# Altium schematic sheet MOSFET Driver.SchDoc("MOSFET Driver")
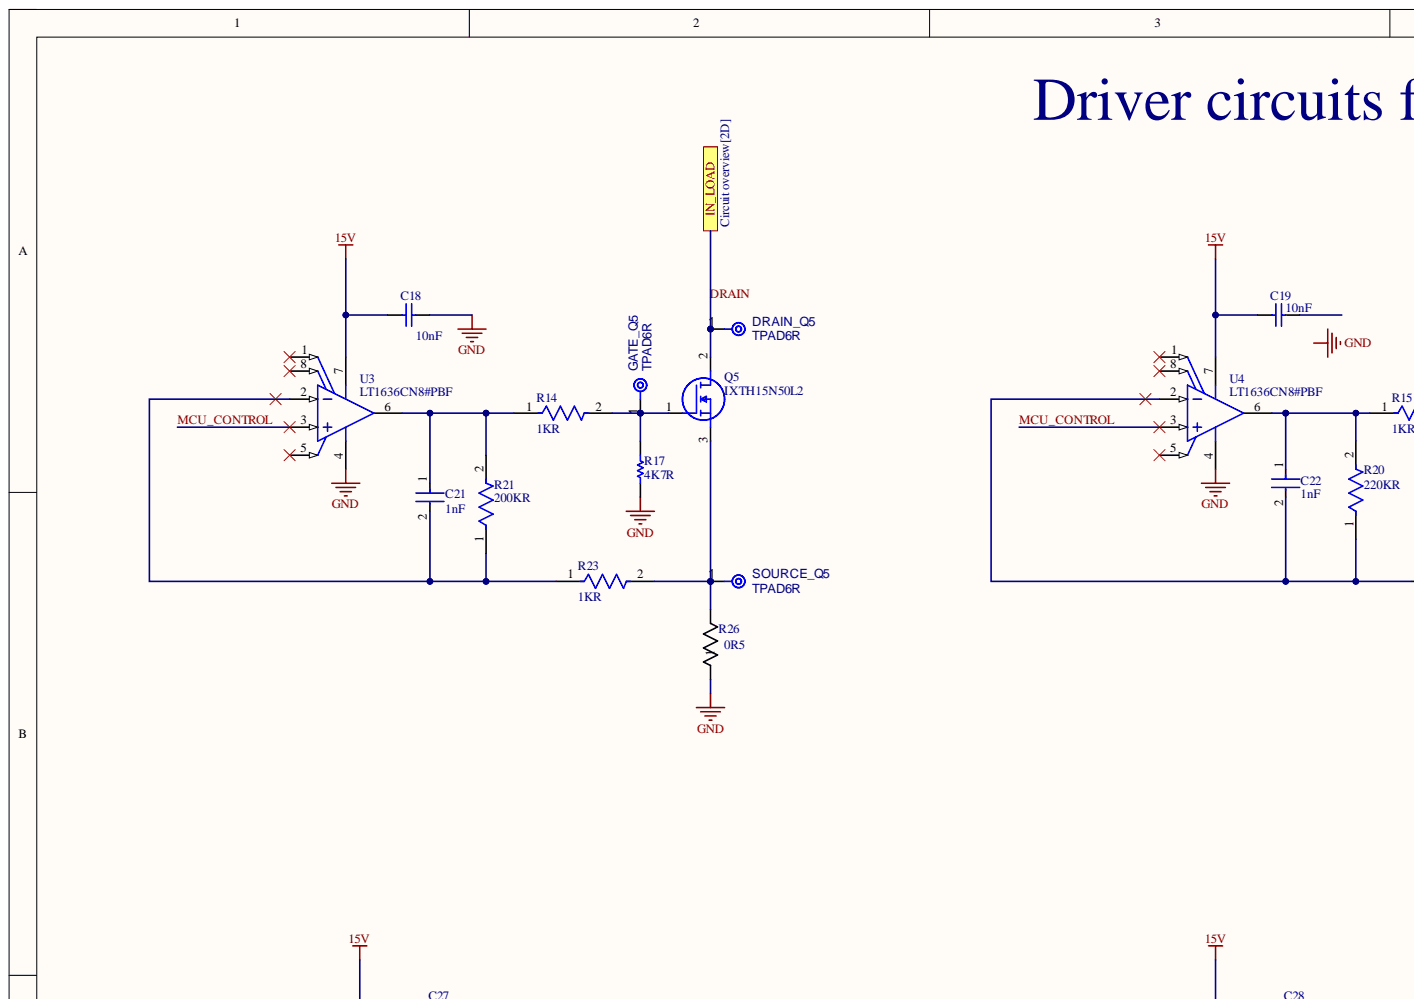
[diagram p0: MOSFET Driver.SchDoc, top left]
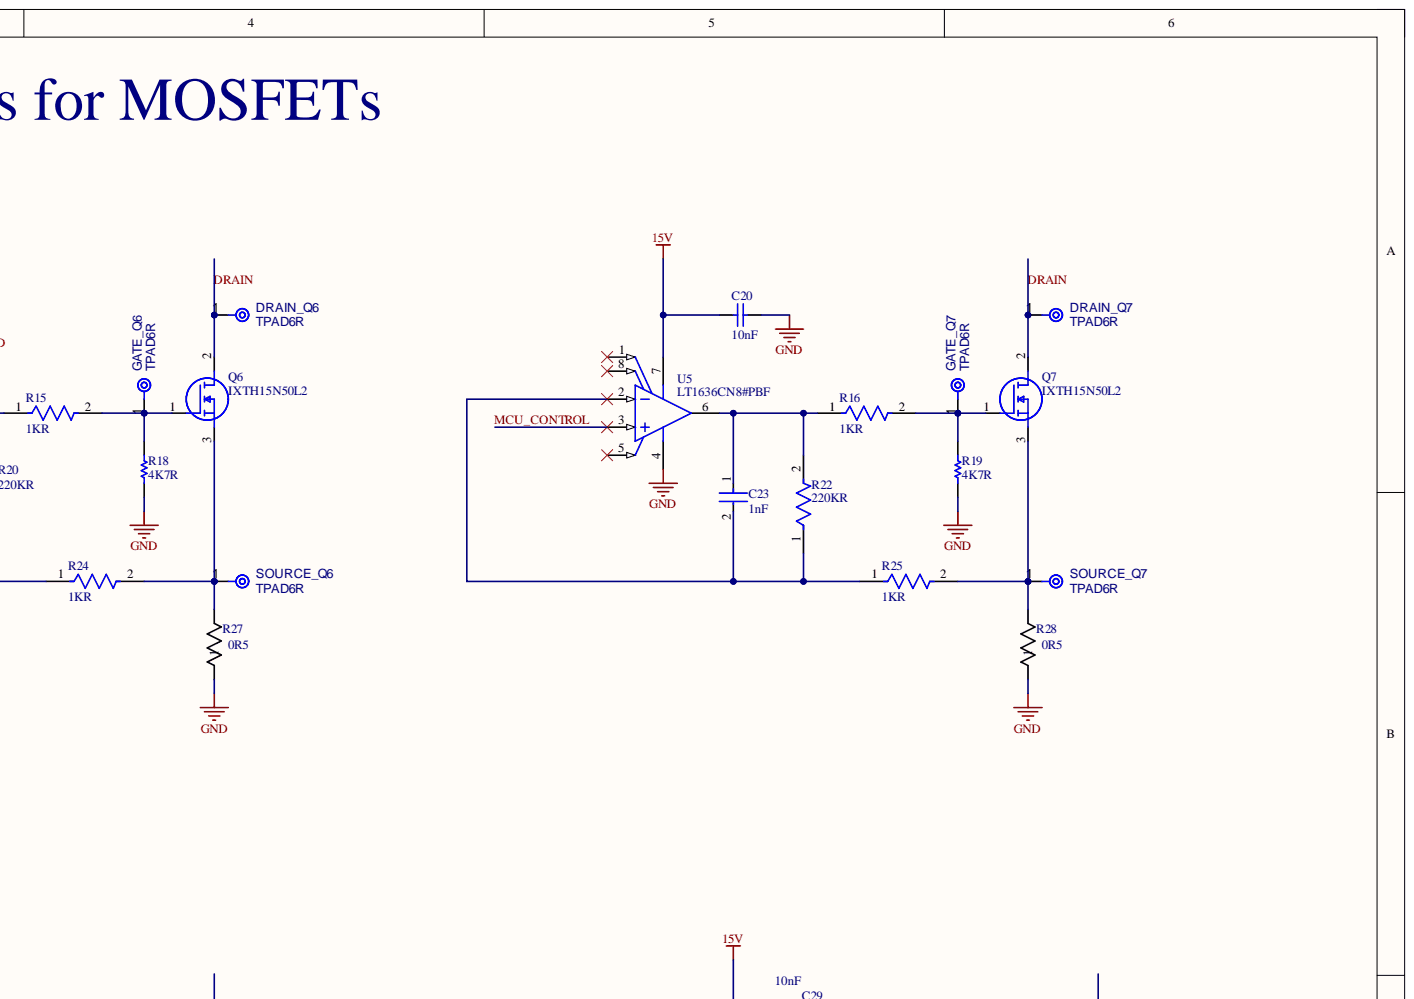
[diagram p1: MOSFET Driver.SchDoc, top right]
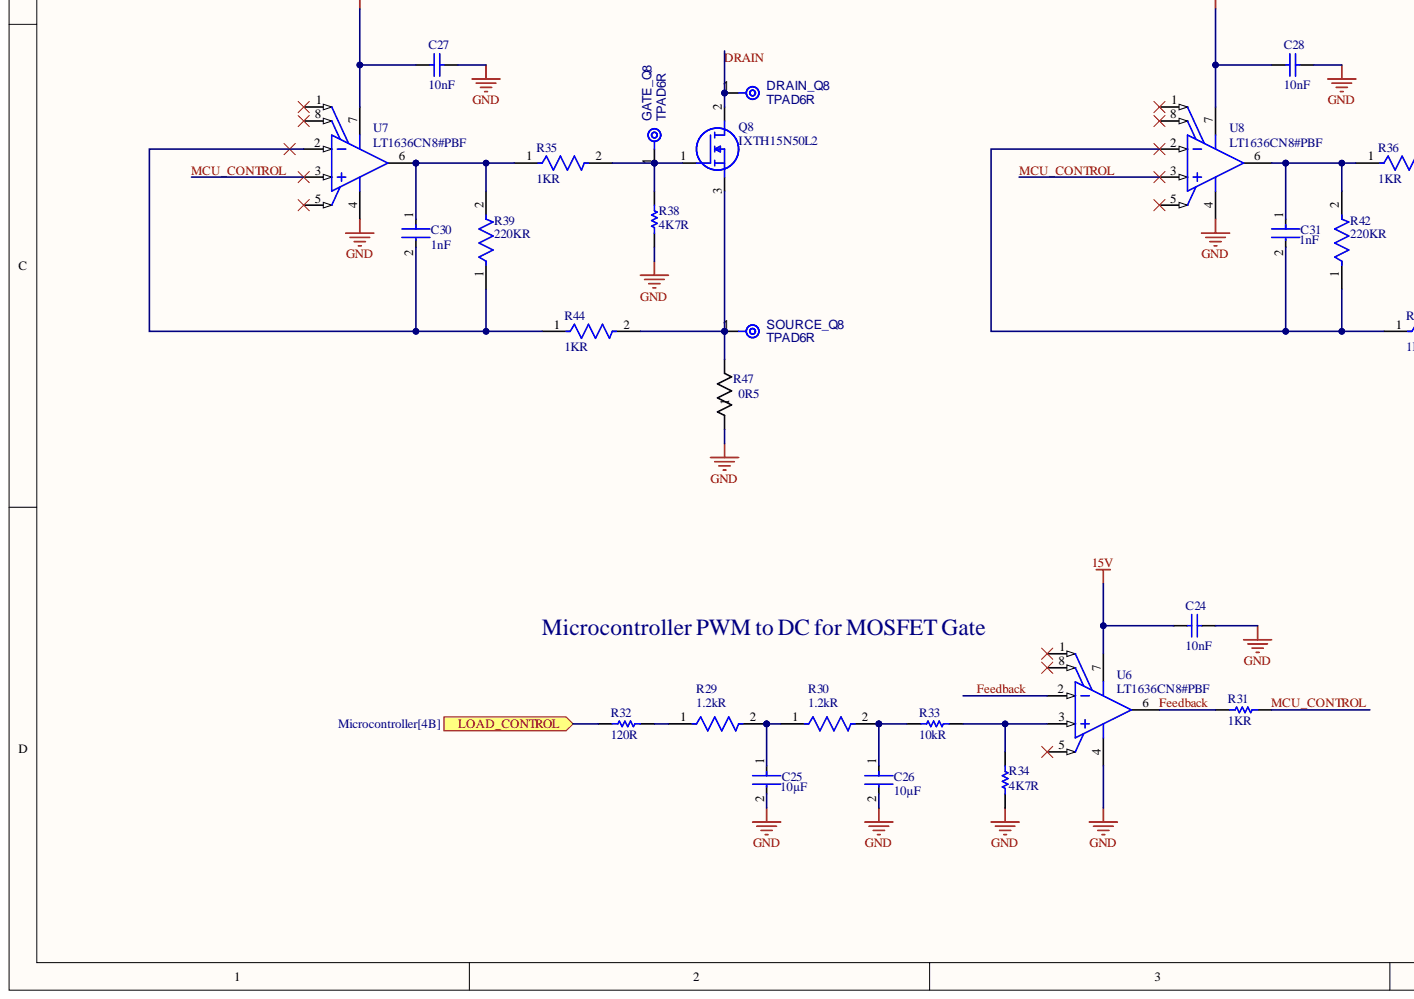
[diagram p2: MOSFET Driver.SchDoc, bottom left]
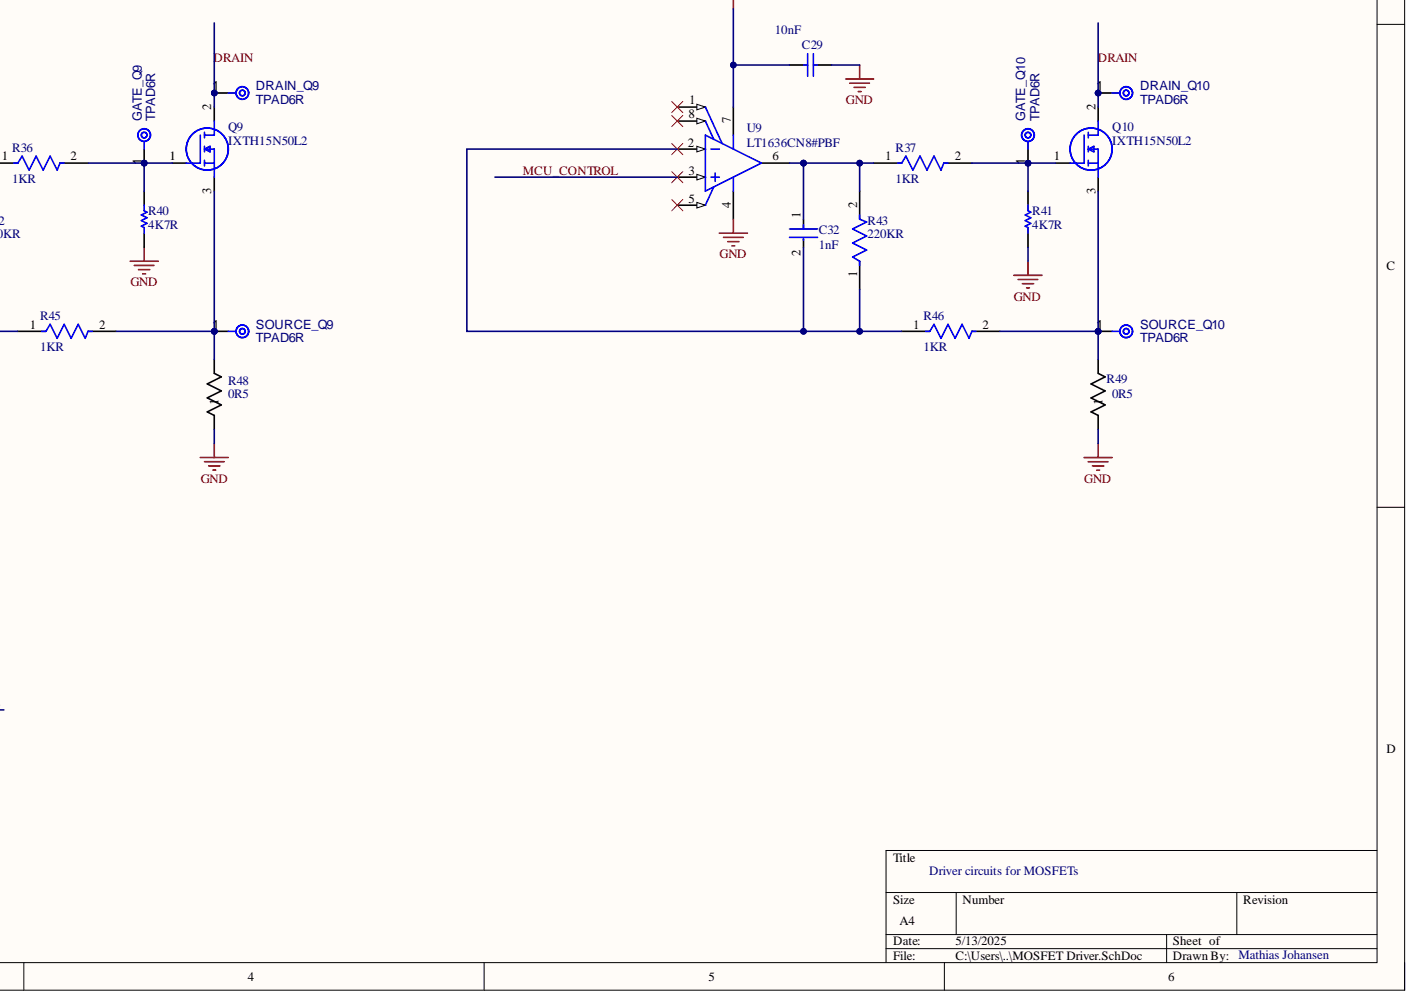
[diagram p3: MOSFET Driver.SchDoc, bottom right]

## Parts
C18  10nF  [CAPC1608X90X35ML15T15]
C19  10nF  [CAPC1608X90X35ML15T15]
C20  10nF  [CAPC1608X90X35ML15T15]
C21  1nF  [FP-CL10-IPC_C]
C22  1nF  [FP-CL10-IPC_C]
C23  1nF  [FP-CL10-IPC_C]
C24  10nF  [CAPC1608X90X35ML15T15]
C25  10µF  [FP-GRM18-0_15-MFG]
C26  10µF  [FP-GRM18-0_15-MFG]
C27  10nF  [CAPC1608X90X35ML15T15]
C28  10nF  [CAPC1608X90X35ML15T15]
C29  10nF  [CAPC1608X90X35ML15T15]
C30  1nF  [FP-CL10-IPC_C]
C31  1nF  [FP-CL10-IPC_C]
C32  1nF  [FP-CL10-IPC_C]
DRAIN_Q5  TPAD6R  [TP06R]  (no BOM)
DRAIN_Q6  TPAD6R  [TP06R]  (no BOM)
DRAIN_Q7  TPAD6R  [TP06R]  (no BOM)
DRAIN_Q8  TPAD6R  [TP06R]  (no BOM)
DRAIN_Q9  TPAD6R  [TP06R]  (no BOM)
DRAIN_Q10  TPAD6R  [TP06R]  (no BOM)
GATE_Q5  TPAD6R  [TP06R]  (no BOM)
GATE_Q6  TPAD6R  [TP06R]  (no BOM)
GATE_Q7  TPAD6R  [TP06R]  (no BOM)
GATE_Q8  TPAD6R  [TP06R]  (no BOM)
GATE_Q9  TPAD6R  [TP06R]  (no BOM)
GATE_Q10  TPAD6R  [TP06R]  (no BOM)
Q5  IXTH15N50L2  [TO545P530X1600X2596-3P]
Q6  IXTH15N50L2  [TO545P530X1600X2596-3P]
Q7  IXTH15N50L2  [TO545P530X1600X2596-3P]
Q8  IXTH15N50L2  [TO545P530X1600X2596-3P]
Q9  IXTH15N50L2  [TO545P530X1600X2596-3P]
Q10  IXTH15N50L2  [TO545P530X1600X2596-3P]
R14  1KR  [FP-AC0603-IPC_B]
R15  1KR  [FP-AC0603-IPC_B]
R16  1KR  [FP-AC0603-IPC_B]
R17  4K7R  [RESC1608X55X25ML10T15]
R18  4K7R  [RESC1608X55X25ML10T15]
R19  4K7R  [RESC1608X55X25ML10T15]
R20  220KR  [FP-CRCW0805-e3-IPC_C]
R21  200KR  [FP-CRCW0805-e3-IPC_C]
R22  220KR  [FP-CRCW0805-e3-IPC_C]
R23  1KR  [FP-AC0603-IPC_B]
R24  1KR  [FP-AC0603-IPC_B]
R25  1KR  [FP-AC0603-IPC_B]
R26  0R5  [RES_VMS-R500-1.0-U_IAT]
R27  0R5  [RES_VMS-R500-1.0-U_IAT]
R28  0R5  [RES_VMS-R500-1.0-U_IAT]
R29  Resistance: 1.2kR  [FP-CRCW0805-e3-MFG]  (hidden comment: CRCW08051K20FKEA)
R30  Resistance: 1.2kR  [FP-CRCW0805-e3-MFG]  (hidden comment: CRCW08051K20FKEA)
R31  1KR  [RESC2013X60X35ML10T20]
R32  120R  [RESC1609X55X30ML15T15]
R33  10kR  [RESC2013X60X35NL10T20]
R34  4K7R  [RESC1608X55X25ML10T15]
R35  1KR  [FP-AC0603-IPC_B]
R36  1KR  [FP-AC0603-IPC_B]
R37  1KR  [FP-AC0603-IPC_B]
R38  4K7R  [RESC1608X55X25ML10T15]
R39  220KR  [FP-CRCW0805-e3-IPC_C]
R40  4K7R  [RESC1608X55X25ML10T15]
R41  4K7R  [RESC1608X55X25ML10T15]
R42  220KR  [FP-CRCW0805-e3-IPC_C]
R43  220KR  [FP-CRCW0805-e3-IPC_C]
R44  1KR  [FP-AC0603-IPC_B]
R45  1KR  [FP-AC0603-IPC_B]
R46  1KR  [FP-AC0603-IPC_B]
R47  0R5  [RES_VMS-R500-1.0-U_IAT]
R48  0R5  [RES_VMS-R500-1.0-U_IAT]
R49  0R5  [RES_VMS-R500-1.0-U_IAT]
SOURCE_Q5  TPAD6R  [TP06R]  (no BOM)
SOURCE_Q6  TPAD6R  [TP06R]  (no BOM)
SOURCE_Q7  TPAD6R  [TP06R]  (no BOM)
SOURCE_Q8  TPAD6R  [TP06R]  (no BOM)
SOURCE_Q9  TPAD6R  [TP06R]  (no BOM)
SOURCE_Q10  TPAD6R  [TP06R]  (no BOM)
U3  LT1636CN8#PBF  [LT-N8-8]
U4  LT1636CN8#PBF  [LT-N8-8]
U5  LT1636CN8#PBF  [LT-N8-8]
U6  LT1636CN8#PBF  [LT-N8-8]
U7  LT1636CN8#PBF  [LT-N8-8]
U8  LT1636CN8#PBF  [LT-N8-8]
U9  LT1636CN8#PBF  [LT-N8-8]

## Ports
IN_LOAD, LOAD_CONTROL

## Nets
15V: C18.1 C19.1 C20.1 C24.1 C27.1 C28.1 C29.1 U3.7 U4.7 U5.7 U6.7 U7.7 U8.7 U9.7
GND: C18.2 C20.2 C24.2 C25.2 C26.2 C27.2 C28.2 C29.2 R17.1 R18.1 R19.1 R26.1 R27.1 R28.1 R34.1 R38.1 R40.1 R41.1 R47.1 R48.1 R49.1 U3.4 U4.4 U5.4 U6.4 U7.4 U8.4 U9.4
DRAIN: DRAIN_Q5.1 DRAIN_Q6.1 DRAIN_Q7.1 DRAIN_Q8.1 DRAIN_Q9.1 DRAIN_Q10.1 Q5.2 Q6.2 Q7.2 Q8.2 Q9.2 Q10.2 ; port IN_LOAD
Feedback: R31.1 U6.2 U6.6
LOAD_CONTROL: R32.1 ; port LOAD_CONTROL
MCU_CONTROL: R31.2 U3.3 U4.3 U5.3 U7.3 U8.3 U9.3
NetC21_1: C21.1 R14.1 R21.2 U3.6
NetC21_2: C21.2 R21.1 R23.1 U3.2
NetC22_1: C22.1 R15.1 R20.2 U4.6
NetC22_2: C22.2 R20.1 R24.1 U4.2
NetC23_1: C23.1 R16.1 R22.2 U5.6
NetC23_2: C23.2 R22.1 R25.1 U5.2
NetC25_1: C25.1 R29.2 R30.1
NetC26_1: C26.1 R30.2 R33.1
NetC30_1: C30.1 R35.1 R39.2 U7.6
NetC30_2: C30.2 R39.1 R44.1 U7.2
NetC31_1: C31.1 R36.1 R42.2 U8.6
NetC31_2: C31.2 R42.1 R45.1 U8.2
NetC32_1: C32.1 R37.1 R43.2 U9.6
NetC32_2: C32.2 R43.1 R46.1 U9.2
NetGATE_Q5_1: GATE_Q5.1 Q5.1 R14.2 R17.2
NetGATE_Q6_1: GATE_Q6.1 Q6.1 R15.2 R18.2
NetGATE_Q7_1: GATE_Q7.1 Q7.1 R16.2 R19.2
NetGATE_Q8_1: GATE_Q8.1 Q8.1 R35.2 R38.2
NetGATE_Q9_1: GATE_Q9.1 Q9.1 R36.2 R40.2
NetGATE_Q10_1: GATE_Q10.1 Q10.1 R37.2 R41.2
NetQ5_3: Q5.3 R23.2 R26.2 SOURCE_Q5.1
NetQ6_3: Q6.3 R24.2 R27.2 SOURCE_Q6.1
NetQ7_3: Q7.3 R25.2 R28.2 SOURCE_Q7.1
NetQ8_3: Q8.3 R44.2 R47.2 SOURCE_Q8.1
NetQ9_3: Q9.3 R45.2 R48.2 SOURCE_Q9.1
NetQ10_3: Q10.3 R46.2 R49.2 SOURCE_Q10.1
NetR29_1: R29.1 R32.2
NetR33_2: R33.2 R34.2 U6.3

Not connected: C19 2; U3 1 5 8; U4 1 5 8; U5 1 5 8; U6 1 5 8; U7 1 5 8; U8 1 5 8; U9 1 5 8

## Library data of the parts
C18-C20 C24 C27-C29 = CMP-2006-02048-1: Manufacturer: AVX Interconnect / Elco; Part Number: 06035C103KAZ2A; Component Kind: Standard; Library Name: Altium Content Vault
C21-C23 C30-C32 = CMP-13271-003275-1: Cap Ceramic 1nF 100V X7R ±10% Pad SMD 0603 +125°C T/R; Capacitance: 1nF; Case Code (Imperial): 0603; Case Code (Metric): 1608; Case/Package: 0603; Component Kind: Standard; Contact Plating: Tin; Depth: 0.8mm; Dielectric: X7R; Height: 0.8mm; Lead Free: Lead Free; Length: 1.6mm; Library Name: Altium Content Vault; Material: Ceramic; Max Operating Temperature: 125°C; Min Operating Temperature: -55°C; Mount: Surface Mount; Package Quantity: 1; Packaging: Tape and Reel; Radiation Hardening: No; Thickness: 0.035inch; Tolerance: 10%; Voltage: 100V; Voltage Rating: 100V; Voltage Rating (DC): 100V; Width: 0.031inch
C25 C26 = CMP-2000-05448-2: CAP CER 10UF 10V X5R 0603; Manufacturer: Murata; Manufacturer Part Number: GRM188R61A106KE69D; Material: Ceramic; Temperature Coefficient: 15%; Tolerance: 10%; Automotive: No; Capacitance: 10uF; Category: Cap; Component Kind: Standard; ComponentLink2Description: Datasheet; ComponentLink2URL: https://search.murata.co.jp/Ceramy/image/img/A01X/G101/ENG/GRM188R61A106KE69-01A.pdf; Device Class L1: Passive Components; Device Class L2: Capacitors; Device Class L3: Ceramic Capacitors; Digikey Description: CAP CER 10UF 10V X5R 0603; Height: 0.95mm; Lead Free: Yes; Library Name: Altium Content Vault; Mouser Description: Multilayer Ceramic Capacitors MLCC - SMD/SMT 0603 10uF 10volts X5R + - 10%; Octopart: https://octopart.com/grm188r61a106ke69d-murata-22851381; Package: GRM18-0.15; RoHS: TRUE; Temperature: -55°C to +85°C; Temperature Characteristic: X5R; Temperature Range High: +85°C; Temperature Range Low: -55°C; Value: 10uF; Voltage Rating: 10V
DRAIN_Q5-DRAIN_Q10 GATE_Q5-GATE_Q10 SOURCE_Q5-SOURCE_Q10 = CMP-00028-00002-2: 0.6mm round; Pins: 1; Library Name: Sondre Pettersen
Q5-Q10 = IXTH15N50L2: MOSFET (N-Channel); Manufacturer_Name: LITTELFUSE; Arrow Part Number: IXTH15N50L2; Arrow Price/Stock: https://www.arrow.com/en/products/ixth15n50l2/ixys; Component Kind: Standard; Datasheet Link: https://www.littelfuse.com/~/media/electronics/datasheets/discrete_mosfets/littelfuse_discrete_mosfets_n-channel_linear_ixt_15n50_datasheet.pdf.pdf; Height: 5.3mm; Manufacturer_Part_Number: IXTH15N50L2; Mouser Part Number: 747-IXTH15N50L2; Mouser Price/Stock: https://www.mouser.co.uk/ProductDetail/IXYS/IXTH15N50L2?qs=cvHLLyFtoE2G218Up1x7gw%3D%3D
R14-R16 R23-R25 R35-R37 R44-R46 = CMP-2000-04828-3: RES 1kΩ 1.00% 0.1W 0603; Max Power Dissipation: 100mW; Temperature Coefficient: 100ppm/°C; Tolerance: 1%; Voltage Rating: 50V; Case Code (Imperial): 0603; Case Code (Metric): 1608; Case/Package: 0603; Component Kind: Standard; Construction: Rectangular; Depth: 0.8mm; Features: Moisture Resistant; Height: 0.022inch; Lead Free: Lead Free; Length: 0.063inch; Library Name: Altium Content Vault; Max Operating Temperature: 155°C; Military Standard: Not; Min Operating Temperature: -55°C; Number of Terminations: 2; Package Quantity: 1; Packaging: Tape and Reel; Power Rating: 100mW; Ratings: AEC-Q200; Resistance: 1kR; Resistor Type: Thick Film; RoHS Compliant: Yes; Series: AC; Size Code: 0603; Termination: SMD/SMT; Width: 0.031inch
R17-R19 R34 R38 R40 R41 = CMP-2000-05024-1: Chip Resistor, 4.7 KOhm, +/- 5%, 100 mW, -55 to 155 degC, 0603 (1608 Metric), RoHS, Tape and Reel; Manufacturer: Yageo; Power Rating: 100mW; Resistance: 4.7kOhms; Temperature Coefficient: 100PPM/C; Voltage Rating: 50V; Case Code - in: 0603; Case Code - mm: 1608; Component Kind: Standard; Datasheet URL: http://www.yageo.com/documents/recent/PYu-RC_Group_51_RoHS_L_04.pdf; Datasheet Version: Rev. 4, 02/2016; Library Name: Altium Content Vault; Manufacturer URL: http://www.yageo.com/; Operating Temperature Range: -55Cto+155C; Package Description: 2-Pin Surface Mount Device, Body 1.6 x 0.8 mm; Package Reference: 603; Packaging: Reel; Product: ThickFilmResistorsGeneralPurpose; Series: RC; Termination Style: SMD/SMT; Tolerance: 5%
R20 R22 R39 R42 R43 = CMP-1013-00772-3: RES Thick Film, 220kΩ, 1%, 0.125W, 100ppm/°C, 0805; Max Power Dissipation: 125mW; Voltage Rating: 150V; Case Code (Imperial): 0805; Case Code (Metric): 2012; Case/Package: 0805; Component Kind: Standard; Composition: Thick Film; Contact Plating: Tin; Depth: 1.25mm; Features: Automotive AEC-Q200; Height: 0.45mm; Lead Free: Lead Free; Length: 2mm; Library Name: Altium Content Vault; Max Operating Temperature: 155°C; Military Standard: Not; Min Operating Temperature: -55°C; Number of Terminations: 2; Package Quantity: 10; Packaging: Tape and Reel; Power Rating: 125mW; Radiation Hardening: No; Resistance: 220kR; Temperature Coefficient: 100ppm/°C; Termination: SMD/SMT; Tolerance: 1%; Voltage Rating (DC): 150V; Weight: 0.000194oz; Width: 1.25mm
R21 = CMP-1013-00772-3: RES Thick Film, 220kΩ, 1%, 0.125W, 100ppm/°C, 0805; Max Power Dissipation: 125mW; Resistance: 220kR; Voltage Rating: 150V; Case Code (Imperial): 0805; Case Code (Metric): 2012; Case/Package: 0805; Component Kind: Standard; Composition: Thick Film; Contact Plating: Tin; Depth: 1.25mm; Features: Automotive AEC-Q200; Height: 0.45mm; Lead Free: Lead Free; Length: 2mm; Library Name: Altium Content Vault; Max Operating Temperature: 155°C; Military Standard: Not; Min Operating Temperature: -55°C; Number of Terminations: 2; Package Quantity: 10; Packaging: Tape and Reel; Power Rating: 125mW; Radiation Hardening: No; Temperature Coefficient: 100ppm/°C; Termination: SMD/SMT; Tolerance: 1%; Voltage Rating (DC): 150V; Weight: 0.000194oz; Width: 1.25mm
R26-R28 R47-R49 = VMS-R500-1.0-U: No Description Available; Mfr_Name: Isabellenhutte; Component Kind: Standard; Library Name: VMS-R500-1P0-U.IntLib; Type: Chip Resistor - Surface Mount
R29 R30 = CMP-2001-03607-2: RES Thick Film, 1.2kΩ, 1%, 0.125W, 100ppm/°C, 0805; Max Power Dissipation: 125mW; Voltage Rating: 150V; Case Code (Imperial): 0805; Case Code (Metric): 2012; Case/Package: 0805; Component Kind: Standard; Composition: Thick Film; Contact Plating: Tin; Depth: 1.25mm; Features: Automotive AEC-Q200; Height: 0.45mm; Lead Free: Lead Free; Length: 2mm; Library Name: Altium Content Vault; Max Operating Temperature: 155°C; Military Standard: Not; Min Operating Temperature: -55°C; Number of Terminations: 2; Package Quantity: 10; Packaging: Tape and Reel; Power Rating: 125mW; Radiation Hardening: No; Temperature Coefficient: 100ppm/°C; Termination: SMD/SMT; Tolerance: 1%; Voltage Rating (DC): 150V; Weight: 0.000194oz; Width: 0.049inch
R31 = CMP-2100-03671-1: Chip Resistor, 1 KOhm, +/- 1%, 125 mW, -55 to 155 degC, 0805 (2012 Metric), RoHS, Tape and Reel; Manufacturer: Yageo; Max Power Dissipation: 125mW; Resistance: 1KOhm; Temperature Coefficient: 100ppm/degreesC; Voltage Rating: 150V; Case Code (Imperial): 0805; Case Code (Metric): 2012; Case/Package: 0805; Component Kind: Standard; Composition: ThickFilm; Datasheet URL: http://www.yageo.com/NewPortal/yageodocoutput?fileName=/pdf/R-Chip/PYu-RC_Group_51_RoHS_L_5.pdf; Datasheet Version: Rev. 5, 10/2016; Depth: 1.25mm; Height: 0.024inch; Lead Free: LeadFree; Length: 0.079inch; Library Name: Altium Content Vault; Manufacturer URL: http://www.yageo.com/; Max Operating Temperature: 155degC; Military Standard: Not; Min Operating Temperature: -55degC; Number of Pins: 2; Number of Terminations: 2; Package Description: 2-Pin Surface Mounted Device, Body 2 x 1.25 mm; Package Quantity: 1; Package Reference: 0805; Packaging: TapeandReel; Power Rating: 125mW; REACH SVHC: Unknown; RoHSCompliant: true; Termination: SMD/SMT; Tolerance: 1%; Voltage Rating (DC): 150V; Width: 0.049inch
R32 = CMP-2000-00213-2: Chip Resistor, 120 Ohm, +/- 1%, 0.1 W, -55 to 155 degC, 0603 (1608 Metric), RoHS, Tape and Reel; Manufacturer: Panasonic; Max Power Dissipation: 100mW; Resistance: 120Ohm; Temperature Coefficient: 100ppm/degreesC; Voltage Rating: 75V; Case Code (Imperial): 0603; Case Code (Metric): 1608; Case/Package: 0603; Component Kind: Standard; Composition: ThickFilm; Contact Plating: Tin; Datasheet URL: https://industrial.panasonic.com/cdbs/www-data/pdf/RDA0000/AOA0000C283.pdf; Datasheet Version: 42095.0; Depth: 0.8mm; Height: 0.022inch; Lead Free: LeadFree; Length: 1.6mm; Library Name: Altium Content Vault; Manufacturer URL: http://www.panasonic.com/; Max Operating Temperature: 155degC; Military Standard: Not; Min Operating Temperature: -55degC; Mount: SurfaceMount; Number of Pins: 2; Number of Terminations: 2; Package Description: 2-Pin Surface Mount Device, Body 1.6 x 0.85 mm; Package Quantity: 1; Package Reference: 0603; Package URL: http://www.st.com/st-web-ui/static/active/en/resource/technical/document/datasheet/CD00001320.pdf; Packaging: TapeandReel; Power Rating: 100mW; Radiation Hardening: No; REACH SVHC: NoSVHC; RoHSCompliant: true; Termination: SMD/SMT; Tolerance: 1%; Voltage Rating (DC): 75V; Width: 0.031inch
R33 = CMP-1659-00013-4: Chip Resistor, 10 KOhm, +/- 1%, 0.125 W, -55 to 155 degC, 0805 (2012 Metric), RoHS, Tape and Reel; Manufacturer: Yageo; Voltage Rating: 150 V; Component Kind: Standard; ComponentLink1Description: Manufacturer URL; ComponentLink1URL: http://www.yageo.com/; ComponentLink2Description: Datasheet; ComponentLink2URL: http://www.yageo.com/documents/recent/PYu-RC_Group_51_RoHS_L_04.pdf; DatasheetVersion: Rev. 4, 02/2016; Height: 0.5 mm; Length: 2 mm; Library Name: Altium Content Vault; Max Operating Temperature: 155 degC; Min Operating Temperature: -55 degC; Mount: Surface Mount; Mounting Technology: Surface Mount; Package Quantity: 5000; PackageDescription: 2-Pin Surface Mounted Device, Body 2 x 1.25 mm; PackageReference: 0805; Packaging: Tape and Reel; Pins: 2; REACH SVHC: No SVHC; RoHSCompliant: true
U3-U9 = CMP-0359-01393-2: Single Channel, Micropower Rail-to-Rail Operational Amplifier, 0.2 MHz Typical GBW, 0.07 V/us Typical SR, 2.6 to 44 V, 8-Pin DIP (N8-8), Commercial, Pb-Free; Linear Technology Part Number: LT1636; Manufacturer: Linear Technology; Channels (#): 1; GBW (MHz) Typical: 0.2; Is (mA) Typical: 0.042; SR (V/us) Typical: 0.07; Vos (mV) Guaranteed: 0.225; Vos (mV) Typical: 0.05; Vs Max (V): 44; Vs Min (V): 2.6; Component Kind: Standard; ComponentLink1Description: Manufacturer URL; ComponentLink1URL: http://www.linear.com/; ComponentLink2Description: Datasheet; ComponentLink2URL: http://cds.linear.com/docs/en/datasheet/1636fc.pdf; ComponentLink3Description: Package Specification; ComponentLink3URL: http://cds.linear.com/docs/en/packaging/05081510_I_N8.pdf; DatasheetVersion: Rev. C; Library Name: Altium Content Vault; Mounting Technology: Surface Mount; PackageDescription: 8-Lead PDIP, Body 10.16 x 6.48 mm, Pitch 2.54 mm; PackageReference: LT-N8-8; PackageVersion: Rev I; PartNumber: LT1636CN8#PBF; RevisionNote: URLs updated; RoHS: Pb-Free; Type: VFB
